# Altium schematic sheet JacdacEc30Hub10x40 114.SchDoc
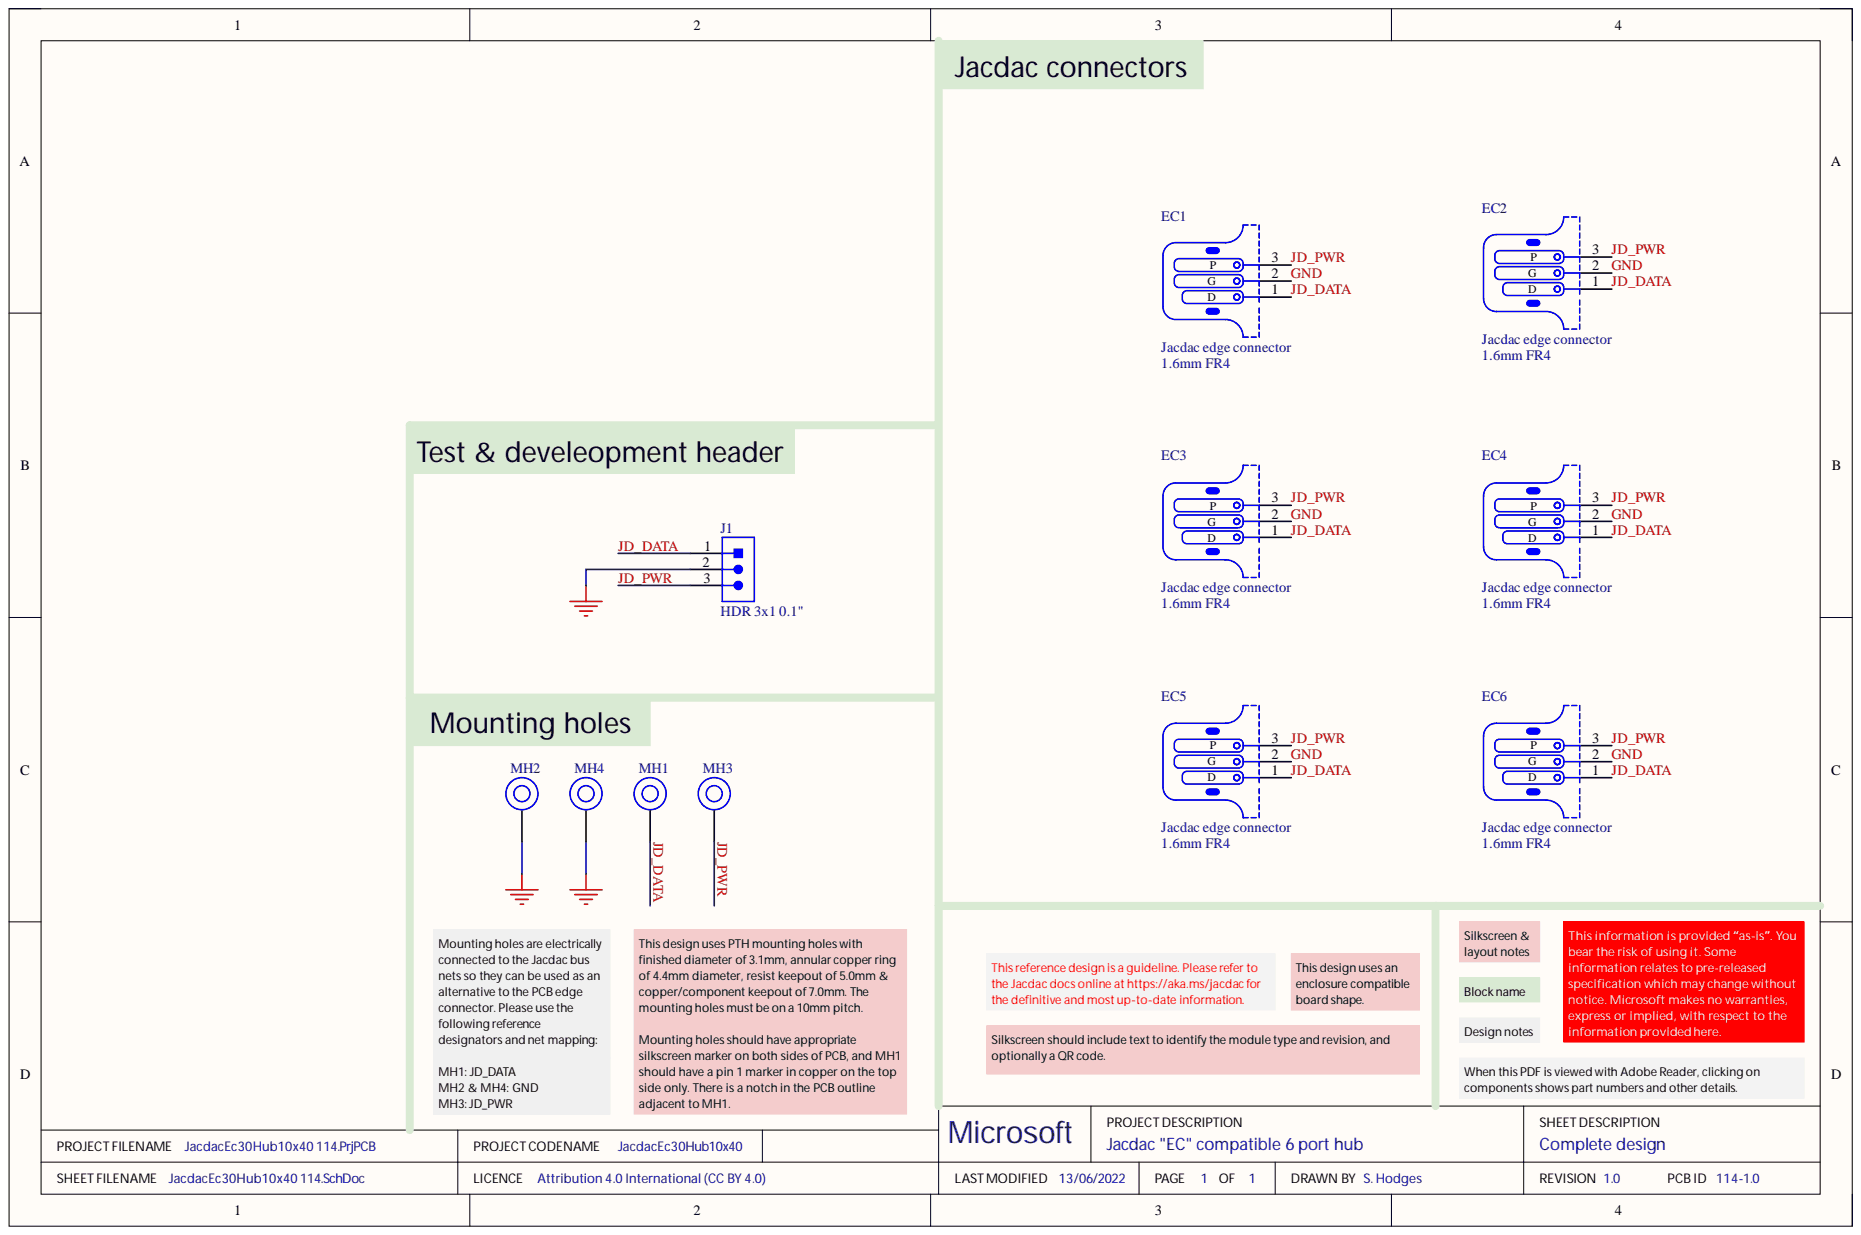
[diagram p0: JacdacEc30Hub10x40 114.SchDoc]

## Parts
EC1  PCB Type: 1.6mm FR4
EC2  PCB Type: 1.6mm FR4
EC3  PCB Type: 1.6mm FR4
EC4  PCB Type: 1.6mm FR4
EC5  PCB Type: 1.6mm FR4
EC6  PCB Type: 1.6mm FR4
J1  (no value)
MH1  (no value)
MH2  (no value)
MH3  (no value)
MH4  (no value)

## Nets
GND: EC1.2 EC2.2 EC3.2 EC4.2 EC5.2 EC6.2 J1.2 MH2.1 MH4.1
JD_DATA: EC1.1 EC2.1 EC3.1 EC4.1 EC5.1 EC6.1 J1.1 MH1.1
JD_PWR: EC1.3 EC2.3 EC3.3 EC4.3 EC5.3 EC6.3 J1.3 MH3.1

## Library data of the parts
EC1-EC6 = (no symbol name): 3 pin Jacdac edge connector 1.27mm pitch; ComponentLink1Description: Yuliang JACDAC cable connector drawing online; ComponentLink1URL: https://github.com/microsoft/jacdac-ddk/tree/main/connector; ComponentLink2Description: JACDAC PCB edge connector spec onlin; ComponentLink2URL: https://github.com/microsoft/jacdac-ddk/tree/main/connector; Manufacturer Part Number: n/a
J1 = (no symbol name): 2.54mm, 3x1 way, vertical, square pin, gold plate; Manufacturer: Multicomp; Manufacturer Part Number: 2211S-03G; ComponentLink1Description: header strip datasheet; ComponentLink1URL: http://www.farnell.com/datasheets/1518407.pdf; Supplier 1: Farnell; Supplier Part Number 1: 1593412
MH1-MH4 = (no symbol name): Mounting Hole 6.5mm keepout, 4.5mm annualar ring and 3.0mm Drill PTH
